# Altium schematic sheet schematic.SchDoc(schematic)
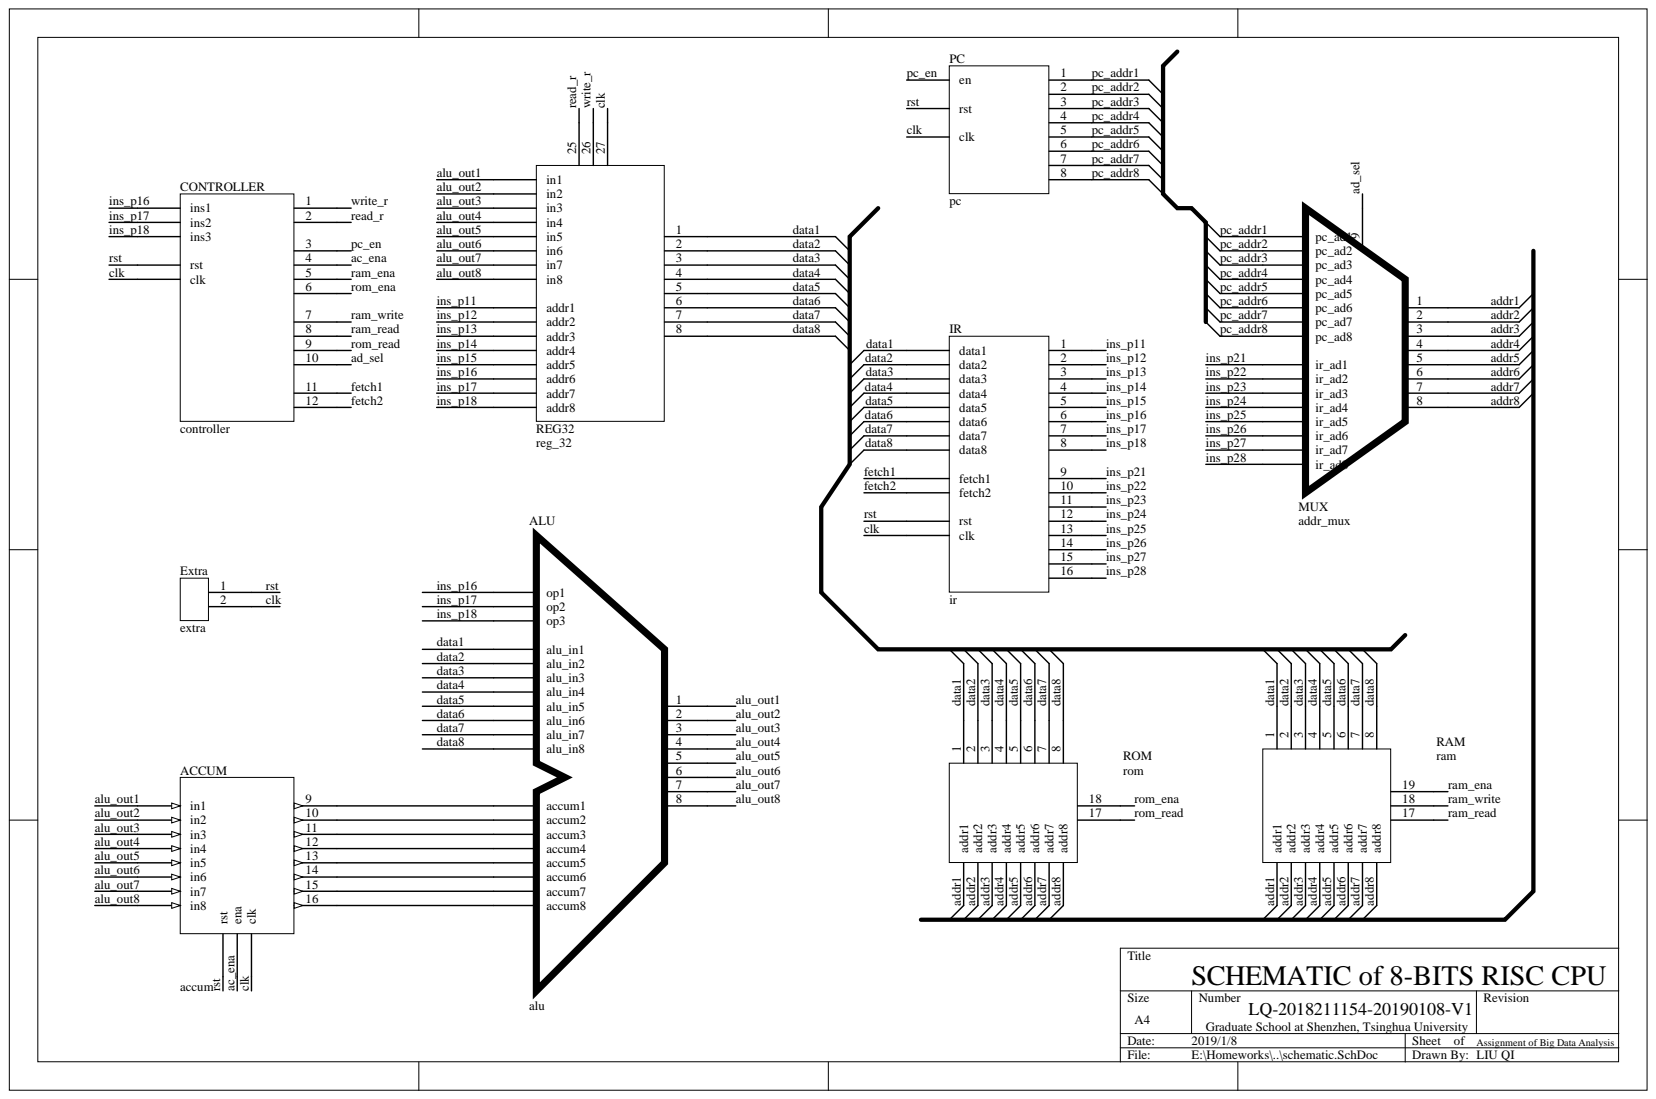
[diagram p0: schematic.SchDoc]

## Parts
ACCUM  accum
ALU  alu
CONTROLLER  controller
Extra  extra
IR  ir
MUX  addr_mux
PC  pc
RAM  ram
REG32  reg_32
ROM  rom

## Nets
ac_ena: ACCUM.19(ena) CONTROLLER.4
ad_sel: CONTROLLER.10 MUX.9
addr1: MUX.1 RAM.9(addr1) ROM.9(addr1)
addr2: MUX.2 RAM.10(addr2) ROM.10(addr2)
addr3: MUX.3 RAM.11(addr3) ROM.11(addr3)
addr4: MUX.4 RAM.12(addr4) ROM.12(addr4)
addr5: MUX.5 RAM.13(addr5) ROM.13(addr5)
addr6: MUX.6 RAM.14(addr6) ROM.14(addr6)
addr7: MUX.7 RAM.15(addr7) ROM.15(addr7)
addr8: MUX.8 RAM.16(addr8) ROM.16(addr8)
alu_out1: ACCUM.1(in1) ALU.1 REG32.9(in1)
alu_out2: ACCUM.2(in2) ALU.2 REG32.10(in2)
alu_out3: ACCUM.3(in3) ALU.3 REG32.11(in3)
alu_out4: ACCUM.4(in4) ALU.4 REG32.12(in4)
alu_out5: ACCUM.5(in5) ALU.5 REG32.13(in5)
alu_out6: ACCUM.6(in6) ALU.6 REG32.14(in6)
alu_out7: ACCUM.7(in7) ALU.7 REG32.15(in7)
alu_out8: ACCUM.8(in8) ALU.8 REG32.16(in8)
clk: ACCUM.17(clk) CONTROLLER.17(clk) Extra.2 IR.31(clk) PC.11(clk) REG32.27
data1: ALU.12(alu_in1) IR.20(data1) RAM.1 REG32.1 ROM.1
data2: ALU.13(alu_in2) IR.21(data2) RAM.2 REG32.2 ROM.2
data3: ALU.14(alu_in3) IR.22(data3) RAM.3 REG32.3 ROM.3
data4: ALU.15(alu_in4) IR.23(data4) RAM.4 REG32.4 ROM.4
data5: ALU.16(alu_in5) IR.24(data5) RAM.5 REG32.5 ROM.5
data6: ALU.17(alu_in6) IR.25(data6) RAM.6 REG32.6 ROM.6
data7: ALU.18(alu_in7) IR.26(data7) RAM.7 REG32.7 ROM.7
data8: ALU.19(alu_in8) IR.27(data8) RAM.8 REG32.8 ROM.8
fetch1: CONTROLLER.11 IR.28(fetch1)
fetch2: CONTROLLER.12 IR.29(fetch2)
ins_p11: IR.1 REG32.17(addr1)
ins_p12: IR.2 REG32.18(addr2)
ins_p13: IR.3 REG32.19(addr3)
ins_p14: IR.4 REG32.20(addr4)
ins_p15: IR.5 REG32.21(addr5)
ins_p16: ALU.9(op1) CONTROLLER.13(ins1) IR.6 REG32.22(addr6)
ins_p17: ALU.10(op2) CONTROLLER.14(ins2) IR.7 REG32.23(addr7)
ins_p18: ALU.11(op3) CONTROLLER.15(ins3) IR.8 REG32.24(addr8)
ins_p21: IR.9 MUX.18(ir_ad1)
ins_p22: IR.10 MUX.19(ir_ad2)
ins_p23: IR.11 MUX.20(ir_ad3)
ins_p24: IR.12 MUX.21(ir_ad4)
ins_p25: IR.13 MUX.22(ir_ad5)
ins_p26: IR.14 MUX.23(ir_ad6)
ins_p27: IR.15 MUX.24(ir_ad7)
ins_p28: IR.16 MUX.25(ir_ad8)
pc_addr1: MUX.10(pc_ad1) PC.1
pc_addr2: MUX.11(pc_ad2) PC.2
pc_addr3: MUX.12(pc_ad3) PC.3
pc_addr4: MUX.13(pc_ad4) PC.4
pc_addr5: MUX.14(pc_ad5) PC.5
pc_addr6: MUX.15(pc_ad6) PC.6
pc_addr7: MUX.16(pc_ad7) PC.7
pc_addr8: MUX.17(pc_ad8) PC.8
pc_en: CONTROLLER.3 PC.9(en)
ram_ena: CONTROLLER.5 RAM.19
ram_read: CONTROLLER.8 RAM.17
ram_write: CONTROLLER.7 RAM.18
read_r: CONTROLLER.2 REG32.25
rom_ena: CONTROLLER.6 ROM.18
rom_read: CONTROLLER.9 ROM.17
rst: ACCUM.18(rst) CONTROLLER.16(rst) Extra.1 IR.30(rst) PC.10(rst)
write_r: CONTROLLER.1 REG32.26
NetACCUM_9: ACCUM.9 ALU.20(accum1)
NetACCUM_10: ACCUM.10 ALU.21(accum2)
NetACCUM_11: ACCUM.11 ALU.22(accum3)
NetACCUM_12: ACCUM.12 ALU.23(accum4)
NetACCUM_13: ACCUM.13 ALU.24(accum5)
NetACCUM_14: ACCUM.14 ALU.25(accum6)
NetACCUM_15: ACCUM.15 ALU.26(accum7)
NetACCUM_16: ACCUM.16 ALU.27(accum8)
N00046:
N00047:
N00048:

## Library data of the parts
IR = ir: Instruction Register; Component Kind: Standard; Library Name: IC_homework_lib.SchLib
RAM = ram: 256Bytes; Component Kind: Standard; Library Name: IC_homework_lib.SchLib
REG32 = reg_32: 32Bytes; Component Kind: Standard; Library Name: IC_homework_lib.SchLib
ROM = rom: 256Bytes; Component Kind: Standard; Library Name: IC_homework_lib.SchLib
ACCUM = accum: Component Kind: Standard; Library Name: IC_homework_lib.SchLib
ALU = alu: Component Kind: Standard; Library Name: IC_homework_lib.SchLib
CONTROLLER = controller: Component Kind: Standard; Library Name: IC_homework_lib.SchLib
Extra = extra: Component Kind: Standard; Library Name: IC_homework_lib.SchLib
MUX = addr_mux: Component Kind: Standard; Library Name: IC_homework_lib.SchLib
PC = pc: Component Kind: Standard; Library Name: IC_homework_lib.SchLib
